# Altium schematic sheet S09_WiFi_JTAG_RevF.SchDoc
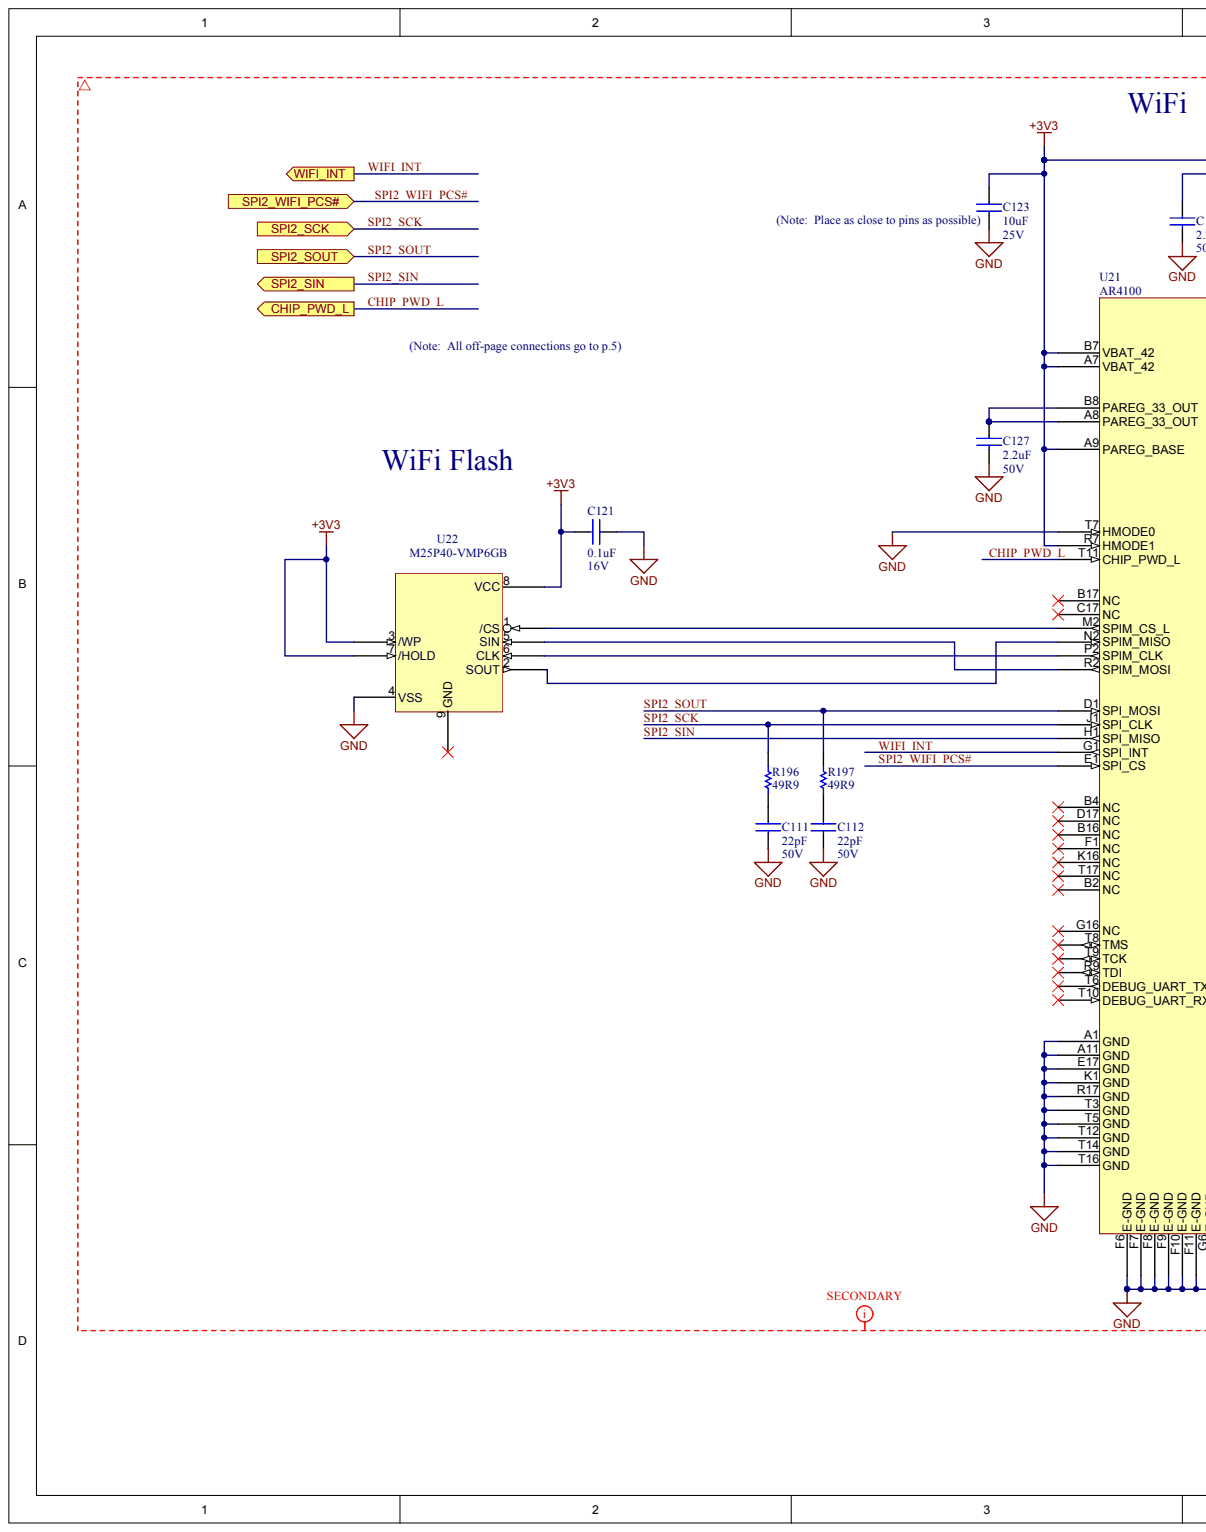
[diagram p0: S09_WiFi_JTAG_RevF.SchDoc, left]
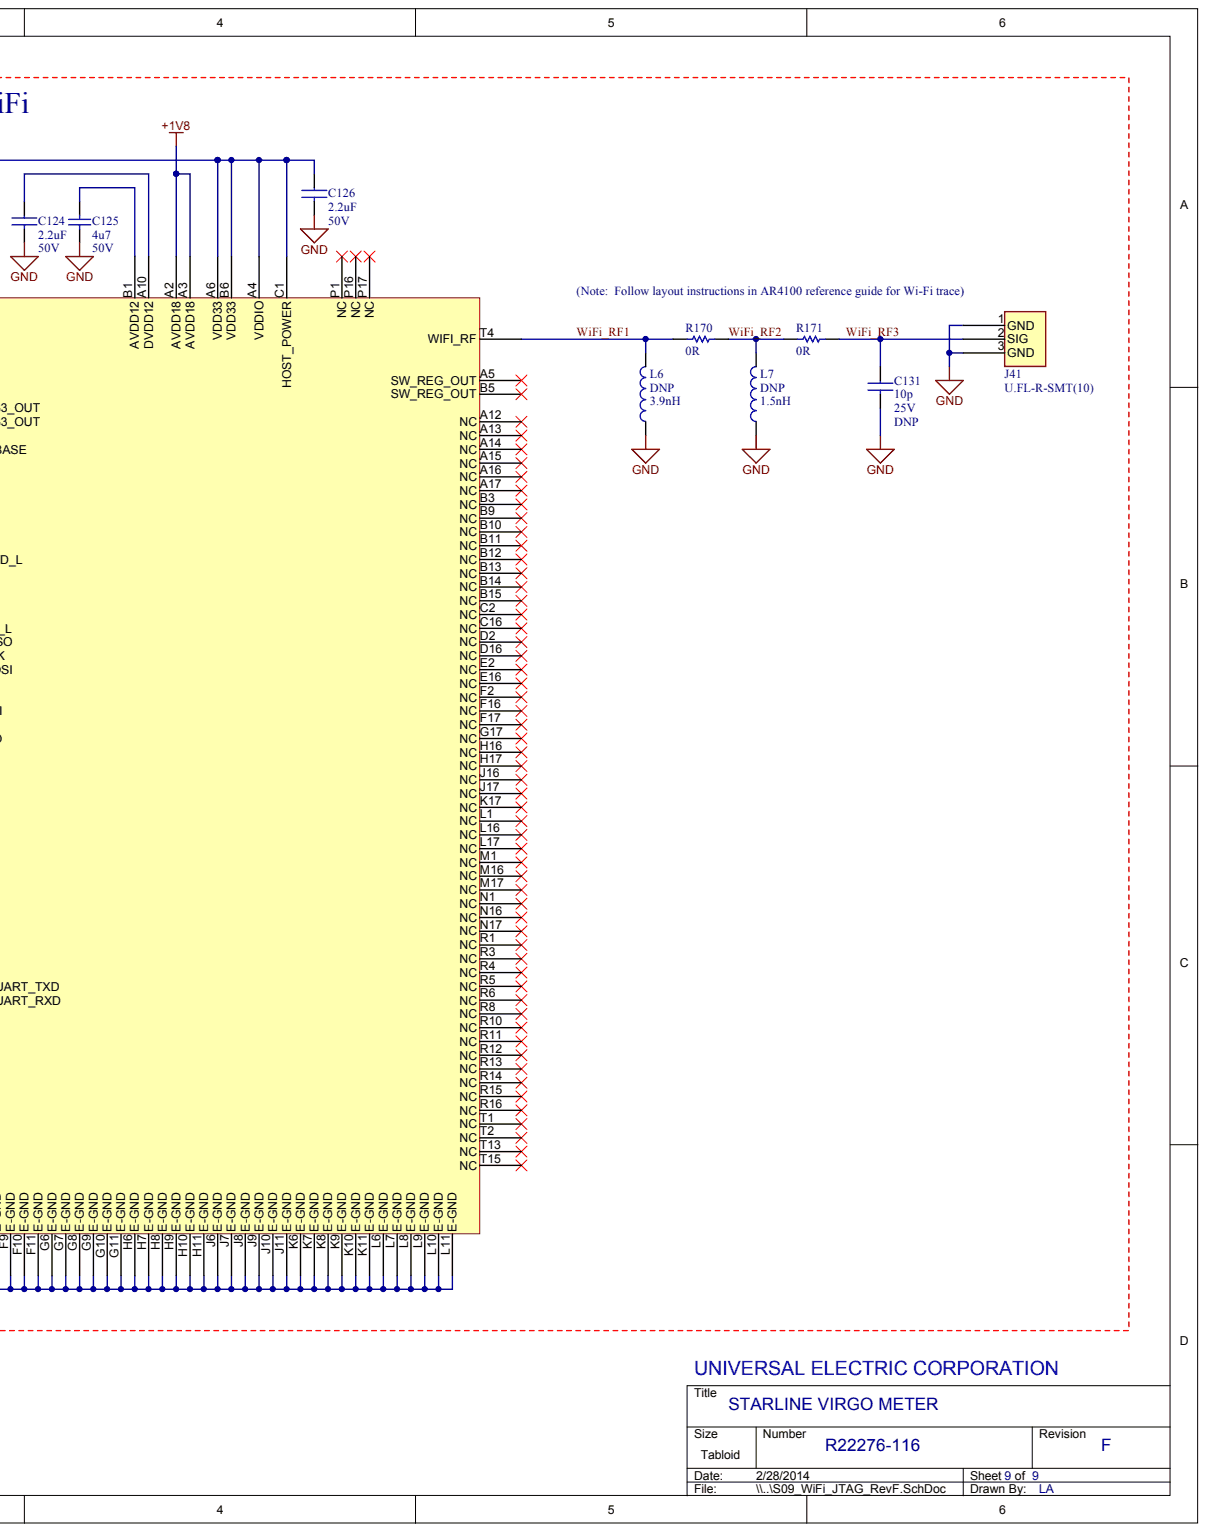
[diagram p1: S09_WiFi_JTAG_RevF.SchDoc, right]

## Parts
C111  Voltage: 50V  (hidden comment: 22pF)
C112  Voltage: 50V  (hidden comment: 22pF)
C121  value 0.1uF  Voltage: 16V  (hidden comment: CAP CER 0.1uF X5R 10% 16V SM_0402)
C123  Voltage: 25V  (hidden comment: 10uF)
C124  Voltage: 50V  (hidden comment: 2.2uF)
C125  value 4u7  VOLTAGE: 50V  (hidden comment: NUV_Cap)
C126  Voltage: 50V  (hidden comment: 2.2uF)
C127  Voltage: 50V  (hidden comment: 2.2uF)
C131  VOLTAGE: 25V  (hidden comment: 10p)
J41  U.FL-R-SMT(10)
L6  3.9nH
L7  1.5nH
R170  value 0R  (hidden comment: RES 0R 1/16W 5% SM_0402)
R171  value 0R  (hidden comment: RES 0R 1/16W 5% SM_0402)
R196  49R9
R197  49R9
U21  AR4100
U22  M25P40-VMP6GB

## Ports
CHIP_PWD_L, SPI2_SCK, SPI2_SIN, SPI2_SOUT, SPI2_WIFI_PCS#, WIFI_INT

## Nets
+1V8: U21.A2(AVDD18) U21.A3(AVDD18)
+3V3: C121.2 C123.1 C126.1 U21.A4(VDDIO) U21.A6(VDD33) U21.A7(VBAT_42) U21.A9(PAREG_BASE) U21.B6(VDD33) U21.B7(VBAT_42) U21.C1(HOST_POWER) U21.R7(HMODE1) U22.3(/WP) U22.7(/HOLD) U22.8(VCC)
GND: C111.2 C112.2 C121.1 C123.2 C124.2 C125.1 C126.2 C127.2 C131.2 J41.1 J41.3 L6.2 L7.2 U21.A1(GND) U21.A11(GND) U21.E17(GND) U21.F6(E-GND) U21.F7(E-GND) U21.F8(E-GND) U21.F9(E-GND) U21.F10(E-GND) U21.F11(E-GND) U21.G6(E-GND) U21.G7(E-GND) U21.G8(E-GND) U21.G9(E-GND) U21.G10(E-GND) U21.G11(E-GND) U21.H6(E-GND) U21.H7(E-GND) U21.H8(E-GND) U21.H9(E-GND) U21.H10(E-GND) U21.H11(E-GND) U21.J6(E-GND) U21.J7(E-GND) U21.J8(E-GND) U21.J9(E-GND) U21.J10(E-GND) U21.J11(E-GND) U21.K1(GND) U21.K6(E-GND) U21.K7(E-GND) U21.K8(E-GND) U21.K9(E-GND) U21.K10(E-GND) U21.K11(E-GND) U21.L6(E-GND) U21.L7(E-GND) U21.L8(E-GND) U21.L9(E-GND) U21.L10(E-GND) U21.L11(E-GND) U21.R17(GND) U21.T3(GND) U21.T5(GND) U21.T7(HMODE0) U21.T12(GND) U21.T14(GND) U21.T16(GND) U22.4(VSS)
CHIP_PWD_L: U21.T11(CHIP_PWD_L) ; port CHIP_PWD_L
SPI2_SCK: R196.2 U21.J1(SPI_CLK) ; port SPI2_SCK
SPI2_SIN: U21.H1(SPI_MISO) ; port SPI2_SIN
SPI2_SOUT: R197.2 U21.D1(SPI_MOSI) ; port SPI2_SOUT
SPI2_WIFI_PCS#: U21.E1(SPI_CS) ; port SPI2_WIFI_PCS#
WIFI_INT: U21.G1(SPI_INT) ; port WIFI_INT
WIFI_RF1: L6.1 R170.1 U21.T4(WIFI_RF)
WIFI_RF2: L7.1 R170.2 R171.1
WIFI_RF3: C131.1 J41.2 R171.2
NetC111_1: C111.1 R196.1
NetC112_1: C112.1 R197.1
NetC124_1: C124.1 U21.A10(DVDD12)
NetC125_2: C125.2 U21.B1(AVDD12)
NetC127_1: C127.1 U21.A8(PAREG_33_OUT) U21.B8(PAREG_33_OUT)
NetU21_M2: U21.M2(SPIM_CS_L) U22.1(/CS)
NetU21_N2: U21.N2(SPIM_MISO) U22.2(SOUT)
NetU21_P2: U21.P2(SPIM_CLK) U22.6(CLK)
NetU21_R2: U21.R2(SPIM_MOSI) U22.5(SIN)
N00539:

Not connected: U21 A5(SW_REG_OUT) A12(NC) A13(NC) A14(NC) A15(NC) A16(NC) A17(NC) B2(NC) B3(NC) B4(NC) B5(SW_REG_OUT) B9(NC) B10(NC) B11(NC) B12(NC) B13(NC) B14(NC) B15(NC) B16(NC) B17(NC) C2(NC) C16(NC) C17(NC) D2(NC) D16(NC) D17(NC) E2(NC) E16(NC) F1(NC) F2(NC) F16(NC) F17(NC) G16(NC) G17(NC) H16(NC) H17(NC) J16(NC) J17(NC) K16(NC) K17(NC) L1(NC) L16(NC) L17(NC) M1(NC) M16(NC) M17(NC) N1(NC) N16(NC) N17(NC) P1(NC) P16(NC) P17(NC) R1(NC) R3(NC) R4(NC) R5(NC) R6(NC) R8(NC) R9(TDI) R10(NC) R11(NC) R12(NC) R13(NC) R14(NC) R15(NC) R16(NC) T1(NC) T2(NC) T6(DEBUG_UART_TXD) T8(TMS) T9(TCK) T10(DEBUG_UART_RXD) T13(NC) T15(NC) T17(NC); U22 9(GND)

## Library data of the parts
C111 C112 = (no symbol name): MFG_PART_NO: CC0402JRNPO9BN220; MFG: Yageo; Value: 22pF
C121 = (no symbol name): MFG_PART_NO: GRM155R71C104KA88D; MFG: Murata
C123 = (no symbol name): MFG_PART_NO: C3216X7R1E106K; MFG: TDK; Value: 10uF
C124 C126 C127 = (no symbol name): MFG_PART_NO: C2012X5R1H225K; MFG: TDK; Value: 2.2uF
C125 = (no symbol name): MFG_PART_NO: 12105C475KAT2A; SYM_LVL: LEVEL2; LEVEL: Level 1; Commodity Code: ECA; MFG: AVX; TYPE: CER; TOLERANCE: 10%; DIELECTRIC: X7R; NUV_DESCRIPTION: Cap, CER, 4u7, X7R, 10%, 50V, SM_1210; COST_1K: 0.702; ALT_SYM: Yes; ORC_SYM: No; VARIATION: 0; Comments: Updated Dielectric; RESERVED1: J.Schriel; ROOM: *; NOSTUFF: *; LAYOUT_FOOTPRINT: 12105C475KAT2A; PACKAGE: SM_1210; ROHS: RoHS; DXD_SYM: No; ALT_FOOT: No; ALEG_FOOT: No; EXP_FOOT: No; REVISION: A; LAST_UPDATE: 4/23/2009; ComponentLink1URL: Datasheets/cx7r.pdf; ComponentLink1Description: Datasheet; ComponentLink2URL: http://www.avx.com/docs/Catalogs/cx7r.pdf; ComponentLink2Description: Datasheet (web)
C131 = (no symbol name): MFG_PART_NO: GRM1555C1E100JA01D; LEVEL: Level_1; PCBLIB: UEC01.PcbLib; MFG: Murata; TYPE: Capacitor; TOLERANCE: 5%; NUV_DESCRIPTION: CAP CER 10PF 25V 5% NP0 0402; DNP: DNP; ROOM: *; VALUE: 10p; PACKAGE: 0402; ROHS: RoHS; LAST_UPDATE: 1/14/2013; Created By: K Desrochers
J41 = (no symbol name): SYM_LVL: LEVEL1; LEVEL: Level_1; PCBLIB: UEC01.PcbLib; MFG: Hirose; NUV_DESCRIPTION: CONN RECPT ULTRA-MINI COAX SMD; NOSTUFF: *; ROOM: *; MFG_PART_NO: U.FL-R-SMT(10); TYPE: Connector; VALUE: U.FL-R-SMT(10); PACKAGE: Thru-Hole; ROHS: RoHS; LAST_UPDATE: 6/27/2012; Created By: K Desrochers
L6 = (no symbol name): MFG_PART_NO: LQP15MN3N9B02D; LEVEL: Level_1; PCBLIB: UEC01.PcbLib; MFG: Murata; TYPE: Inductor; CURRENT: 170mA; NUV_DESCRIPTION: INDUCTOR 3.9NH 170MA 0402; DNP: DNP; VALUE: 3.9nH; PACKAGE: 0402; ROHS: RoHS; LAST_UPDATE: 1/14/2013; Created By: K Desrochers; Checked By: N.Wennyk
L7 = (no symbol name): MFG_PART_NO: LQP15MN1N5B02D; LEVEL: Level_1; PCBLIB: UEC01.PcbLib; MFG: Murata; TYPE: Inductor; CURRENT: 280mA; NUV_DESCRIPTION: INDUCTOR 1.5NH 280MA 0402; DNP: DNP; VALUE: 1.5nH; PACKAGE: 0402; ROHS: RoHS; LAST_UPDATE: 1/14/2013; Created By: K Desrochers; Checked By: N.Wennyk
R170 R171 = (no symbol name): MFG_PART_NO: CRCW04020000Z0ED; MFG: Vishay
R196 R197 = (no symbol name): MFG_PART_NO: RC0402FR-0749R9L; SYM_LVL: LEVEL1; LEVEL: Level_1; PCBLIB: UEC01.PcbLib; MFG: Yageo; TOLERANCE: +/-1%; NUV_DESCRIPTION: RES 50OHM 1/16W SMD; ROOM: *; NOSTUFF: *; VOLTAGE: =VOLTAGE; VALUE: 49R9; TYPE: Resistor; PACKAGE: SMT; ROHS: RoHS; Created By: H.Munir
U21 = (no symbol name): SYM_LVL: LEVEL1; PCBLIB: UEC01.PcbLib; MFG: Qualcomm Atheros; NUV_DESCRIPTION: System in Package 802.11n,  LGA-99; ROOM: *; MFG_PART_NO: AR4100; TYPE: IC; VALUE: AR4100; PACKAGE: SMT; ROHS: RoHS
U22 = (no symbol name): MFG: Micron; MFG_PART_NO: M25P40-VMP6GB; VALUE: M25P40-VMP6GB
